# Altium schematic sheet version1.SchDoc
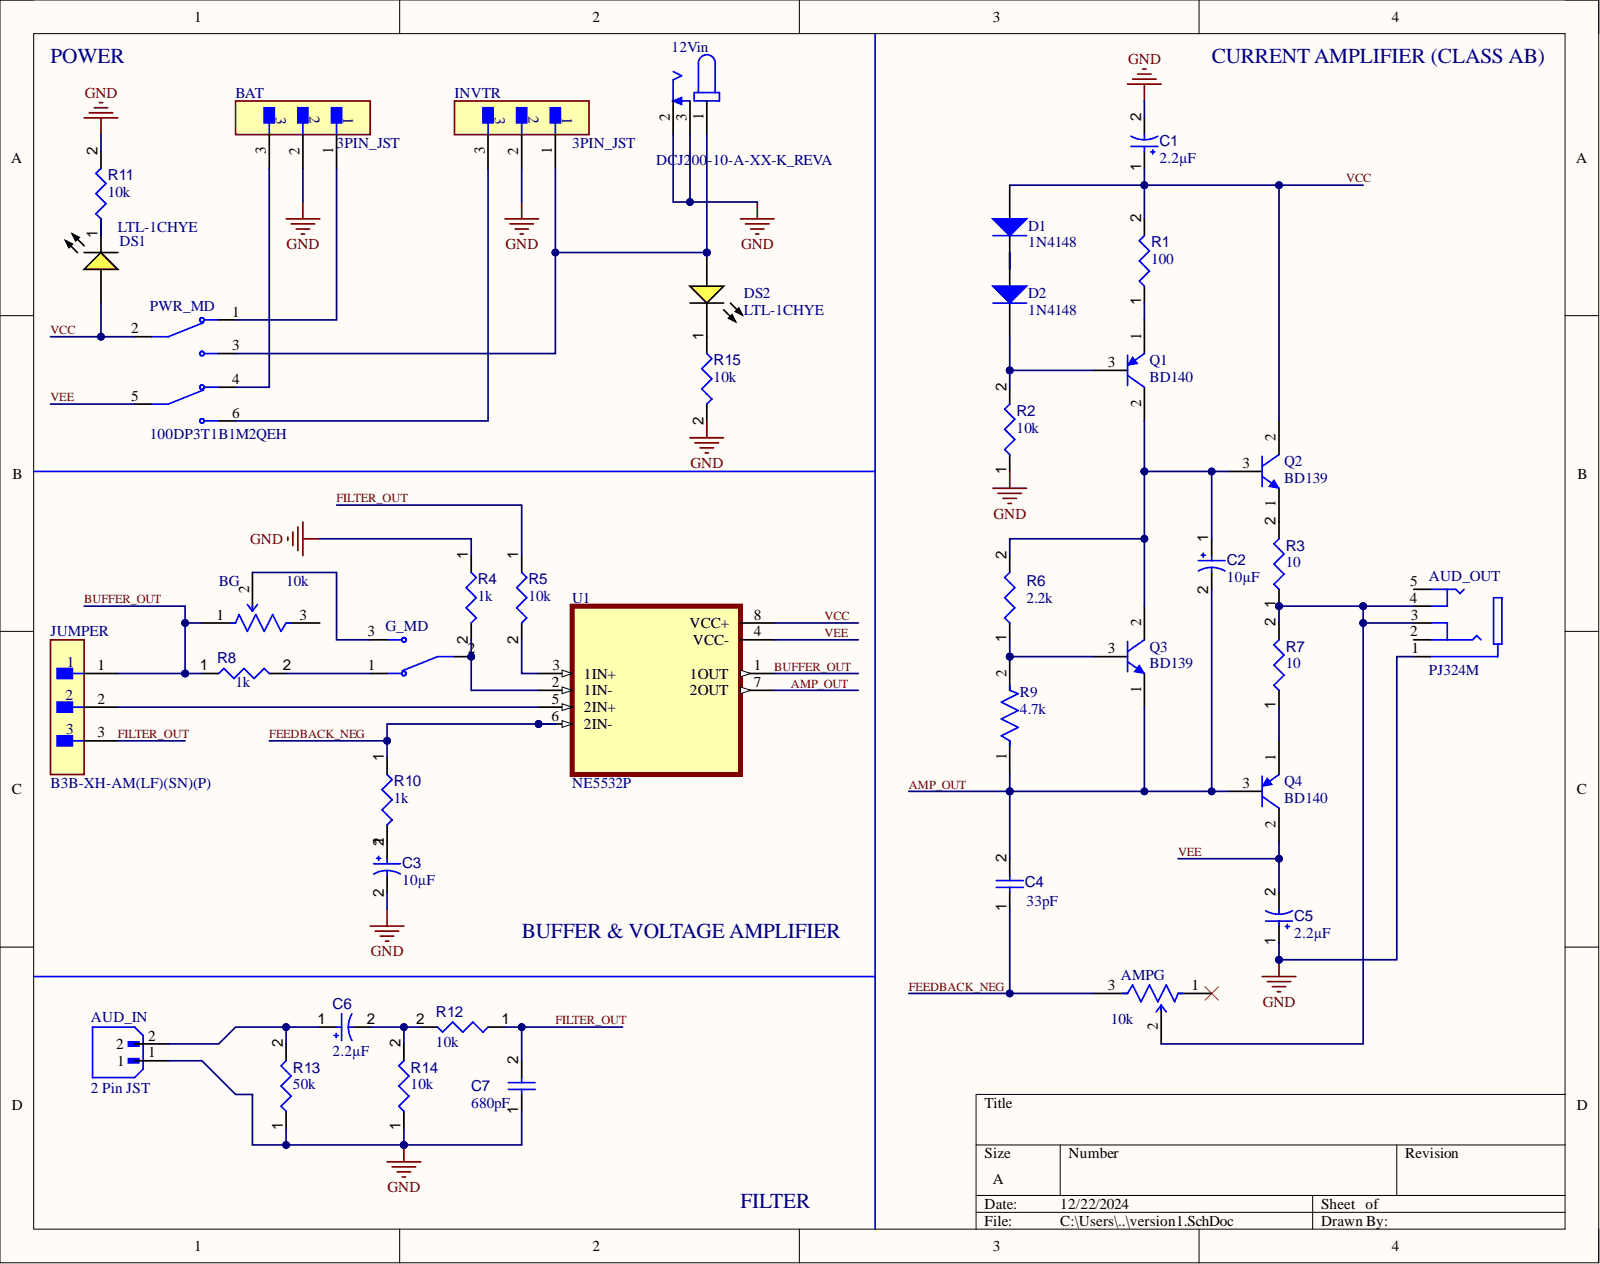
[diagram p0: version1.SchDoc]

## Parts
12Vin  DCJ200-10-A-XX-K_REVA  [GCT_DCJ200-10-A-XX-K_REVA]
AMPG  value 10k  [FP-248FGJSPXB25102MA-MFG - Cloned]  (hidden comment: 10K Potentiometer)
AUD_IN  2 Pin JST  [JST_B2B-XH-A(LF)(SN)]
AUD_OUT  PJ324M  [WENZHOU_PJ324M]
BAT  3PIN_JST  [FP-B3B-XH-AM_LF_SN_P-MFG]
BG  value 10k  [FP-248FGJSPXB25102MA-MFG - Cloned]  (hidden comment: 10K Potentiometer)
C1  value 2.2µF  [CAPPR55-200-1100X500X1200]  (hidden comment: ECA1HM2R2)
C2  value 10µF  [CAPPR55-200-1100X500X1200]  (hidden comment: ECA1HM100)
C3  value 10µF  [CAPPR55-200-1100X500X1200]  (hidden comment: ECA1HM100)
C4  value 33pF  [FP-D330G20C0GH63J5R-MFG]  (hidden comment: 33pF THT Capacitor)
C5  value 2.2µF  [CAPPR55-200-1100X500X1200]  (hidden comment: ECA1HM2R2)
C6  value 2.2µF  [CAPPR55-200-1100X500X1200]  (hidden comment: ECA1HM2R2)
C7  value 680pF  [FP-RCE0DB-MFG]  (hidden comment: 680pF THT Capacitor)
D1  1N4148  [NXP-SOD27-2_V]
D2  1N4148  [NXP-SOD27-2_V]
DS1  LTL-1CHYE  [LTST-LTL-1CHYE]
DS2  LTL-1CHYE  [LTST-LTL-1CHYE]
G_MD  (no value)  [SW_100SP1T1B4M2QE]
INVTR  3PIN_JST  [FP-B3B-XH-AM_LF_SN_P-MFG]
JUMPER  B3B-XH-AM(LF)(SN)(P)  [3 PIN HEADER]
PWR_MD  100DP3T1B1M2QEH  [SW_100DP3T1B1M2QEH]
Q1  BD140  [ONSC-TO-225-3-77-09]
Q2  BD139  [ONSC-TO-225-3-77-09]
Q3  BD139  [ONSC-TO-225-3-77-09]
Q4  BD140  [ONSC-TO-225-3-77-09]
R1  value 100  [FP-CFR50J2K2-MFG]  (hidden comment: 100 0.5W THT Resistor)
R2  value 10k  [FP-CFR50J2K2-MFG]  (hidden comment: 10k 0.5W THT Resistor)
R3  value 10  [FP-CFR50J2K2-MFG]  (hidden comment: 10 0.5W THT Resistor)
R4  value 1k  [FP-CFR50J2K2-MFG]  (hidden comment: 10k 0.5W THT Resistor)
R5  value 10k  [FP-CFR50J2K2-MFG]  (hidden comment: 10k 0.5W THT Resistor)
R6  value 2.2k  [FP-CFR50J2K2-MFG]  (hidden comment: 2.2k 0.5W Resistor THT)
R7  value 10  [FP-CFR50J2K2-MFG]  (hidden comment: 10 0.5W THT Resistor)
R8  value 1k  [FP-CFR50J2K2-MFG]  (hidden comment: 10k 0.5W THT Resistor)
R9  value 4.7k  [FP-CFR50J2K2-MFG]  (hidden comment: 4.7k 0.5W Resistor THT)
R10  value 1k  [FP-CFR50J2K2-MFG]  (hidden comment: 10k 0.5W THT Resistor)
R11  value 10k  [FP-CFR50J2K2-MFG]  (hidden comment: 10k 0.5W THT Resistor)
R12  value 10k  [FP-CFR50J2K2-MFG]  (hidden comment: 10k 0.5W THT Resistor)
R13  value 50k  [FP-CFR50J2K2-MFG]  (hidden comment: 50k 0.5W THT Resistor)
R14  value 10k  [FP-CFR50J2K2-MFG]  (hidden comment: 10k 0.5W THT Resistor)
R15  value 10k  [FP-CFR50J2K2-MFG]  (hidden comment: 10k 0.5W THT Resistor)
U1  NE5532P  [DIP794W45P254L959H508Q8]

## Nets
GND: 12Vin.2 12Vin.3 AUD_IN.1 AUD_OUT.1 BAT.2 C1.2 C3.2 C5.1 C7.1 INVTR.2 R2.1 R4.1 R11.2 R13.1 R14.1 R15.2
AMP_OUT: C2.2 C4.2 Q3.1 Q4.3 R9.1 U1.7(2OUT)
BUFFER_OUT: BG.1 JUMPER.1 R8.1 U1.1(1OUT)
FEEDBACK_NEG: AMPG.3 C4.1 R10.1 U1.6(2IN-)
FILTER_OUT: C7.2 JUMPER.3 R5.1 R12.1
VCC: C1.1 D1.1 DS1.1 PWR_MD.2 Q2.2 R1.2 U1.8(VCC+)
VEE: C5.2 PWR_MD.5 Q4.2 U1.4(VCC-)
Net12Vin_1: 12Vin.1 DS2.1 INVTR.1 PWR_MD.3
NetAMPG_2: AMPG.2 AUD_OUT.3 AUD_OUT.4 R3.1 R7.2
NetAUD_IN_2: AUD_IN.2 C6.1 R13.2
NetBAT_1: BAT.1 PWR_MD.1
NetBAT_3: BAT.3 PWR_MD.4
NetBG_2: BG.2 G_MD.3
NetC2_1: C2.1 Q1.2 Q2.3 Q3.2 R6.2
NetC3_1: C3.1 R10.2
NetC6_2: C6.2 R12.2 R14.2
NetD1_2: D1.2 D2.1
NetD2_2: D2.2 Q1.3 R2.2
NetDS1_2: DS1.2 R11.1
NetDS2_2: DS2.2 R15.1
NetG_MD_1: G_MD.1 R8.2
NetG_MD_2: G_MD.2 R4.2 U1.2(1IN-)
NetINVTR_3: INVTR.3 PWR_MD.6
NetJUMPER_2: JUMPER.2 U1.5(2IN+)
NetQ1_1: Q1.1 R1.1
NetQ2_1: Q2.1 R3.2
NetQ3_3: Q3.3 R6.1 R9.2
NetQ4_1: Q4.1 R7.1
NetR5_2: R5.2 U1.3(1IN+)

Not connected: AMPG 1; AUD_OUT 2 5; BG 3

## Library data of the parts
12Vin = DCJ200-10-A-XX-K_REVA: Power Barrel Connector Jack 2.05mm ID (0.081), 5.50mm OD (0.217) Through Hole, Right Angle; MF: Global Connector Technology; MP: DCJ200-10-A-K1-K; Check_prices: https://www.snapeda.com/parts/DCJ200-10-A-K1-K/Global+Connector+Technology/view-part/?ref=eda; Component Kind: Standard; Package: None; SnapEDA_Link: https://www.snapeda.com/parts/DCJ200-10-A-K1-K/Global+Connector+Technology/view-part/?ref=snap; Library Name: GHA_SCHLib.SchLib
AMPG BG = potentiometer 10K: 10K Potentiometer, B10K; Component Kind: Standard; Library Name: GHA_SCHLib.SchLib
AUD_IN = B2B-XH-A(LF)(SN): Connector Header Through Hole 2 position 0.098 (2.50mm); MF: JST Sales America Inc.; Check_prices: https://www.snapeda.com/parts/B2B-XH-A(LF)(SN)/JST+Sales+America+Inc./view-part/?ref=eda; Component Kind: Standard; MP: B2B-XH-A(LF)(SN); Package: None; SnapEDA_Link: https://www.snapeda.com/parts/B2B-XH-A(LF)(SN)/JST+Sales+America+Inc./view-part/?ref=snap; Library Name: GHA_SCHLib.SchLib
AUD_OUT = PJ324M: Headphone Stereo jack/Audio connector; MF: Wenzhou QingPu Electronics Co.Ltd; Check_prices: https://www.snapeda.com/parts/PJ324M/Wenzhou+QingPu+Electronics+Co.Ltd/view-part/?ref=eda; Component Kind: Standard; MP: PJ324M; Package: Package; SnapEDA_Link: https://www.snapeda.com/parts/PJ324M/Wenzhou+QingPu+Electronics+Co.Ltd/view-part/?ref=snap; Library Name: GHA_SCHLib.SchLib
BAT INVTR JUMPER = JST-XH-3pin: CONN HEADER VERT 3POS 2.5MM; Connector Type: Male Pin; Number of Positions: 3; Pitch: 0.098inch; Color: Natural; Component Kind: Standard; Contact Plating: Tin; Contact Type: Male Pin; Mount: Through Hole; Number of Rows: 1; Package Quantity: 1000; Packaging: Bulk; Series: XH; Termination: Press-Fit
  BAT INVTR: Library Name: SchemLib.SchLib
  JUMPER: Library Name: GHA_SCHLib.SchLib
C1 C5 C6 = CMP-001-00029-7: Aluminum Electrolytic Capacitor, 2.2 uF, +/- 20%, 50 V, -40 to 85 degC, 2-Pin THD, RoHS, Bulk; Manufacturer: Panasonic; Ripple Current: 20mA; Voltage Rating: 50V; Capacitor Type: Electrolytic; Case/Package: Radial; Component Kind: Standard; Datasheet URL: https://industrial.panasonic.com/cdbs/www-data/pdf/RDF0000/ABA0000C1218.pdf; Datasheet Version: Rev. 05, 10/2016; Diameter: 5mm; Lead Pitch: 2mm; Manufacturer URL: http://www.panasonic.com/; Max Operating Temperature: 85°C; Min Operating Temperature: -40°C; Mounting Technology: TH; Package Description: 2-Pin Radial Lead, Dia 5 mm, Height 12 mm; Package Quantity: 200; Package Reference: CAPPR55-200-1100X500X1200; Packaging: Bulk; Pins: 2; Ripple Current (AC): 20mA; RoHS Compliant: True; Tolerance: 20%; Library Name: Kiran Gunathilaka
C2 C3 = CMP-001-00025-7: Aluminum Electrolytic Capacitor, 10 uF, +/- 20%, 50 V, -40 to 85 degC, 2-Pin THD, RoHS, Bulk; Manufacturer: Panasonic; Ripple Current: 65mA; Voltage Rating: 50V; Capacitor Type: Electrolytic; Case/Package: Radial; Component Kind: Standard; Datasheet URL: https://industrial.panasonic.com/cdbs/www-data/pdf/RDF0000/ABA0000C1218.pdf; Datasheet Version: Rev. 05, 10/2016; Diameter: 5mm; Lead Pitch: 2mm; Manufacturer URL: http://www.panasonic.com/; Max Operating Temperature: 85°C; Min Operating Temperature: -40°C; Mounting Technology: TH; Package Description: 2-Pin Radial Lead, Dia 5 mm, Height 12 mm; Package Quantity: 200; Package Reference: CAPPR55-200-1100X500X1200; Packaging: Bulk; Pins: 2; Ripple Current (AC): 65mA; RoHS Compliant: True; Tolerance: 20%; Library Name: Kiran Gunathilaka
C4 = CMP-001-00083-1: Ceramic Capacitor, Ceramic, 100V, 2% +Tol, 2% -Tol, C0G, 30ppm/Cel TC, 0.000033uF, Through Hole Mount; Multilayer: No; Capacitor Type: Ceramic; Component Kind: Standard; Dielectric Material: Ceramic; Height: 9mm; JESD-609 Code: e3; Length: 5mm; Max Operating Temperature: 125°C; Min Operating Temperature: -55°C; Mounting Technology: Through Hole; Package Shape: Disk; Package Style: RadialMeter; Packaging: Bulk; Pins: 2; Rated DC Voltage (URdc): 100V; RoHS Compliant: Yes; Temperature Characteristics Code: C0G; Terminal Finish: Tin; Terminal Pitch: 5mm; Termination: Wire; Tolerance  (fill in as +-): 2%; Tolerance (fill in as +-): 2%; Width: 3.5mm; Library Name: Kiran Gunathilaka
C7 = CMP-001-00084-1: RCER71H681K0DBH03A          Ceramic Capacitor, Multilayer, Ceramic, 50V, 10% +Tol, 10% -Tol, X7R, 15% TC, 0.00068uF, Through Hole Mount, 1410; Reference Standard: AEC-Q200; Capacitor Type: Ceramic; Case/Package: 1410; Component Kind: Standard; Dielectric Material: Ceramic; Height: 3.5mm; Length: 3.6mm; Max Operating Temperature: 125°C; Min Operating Temperature: -55°C; Package Style: RadialMeter; Packaging: Ammo Pack; Pins: 2; Rated DC Voltage (URdc): 50V; Reach SVHC Compliant: Yes; RoHS Compliant: Yes; Temperature Characteristics Code: X7R; Terminal Pitch: 2.5mm; Tolerance  (fill in as +-): 10%; Tolerance (fill in as +-): 10%; Width: 2.5mm; Library Name: Kiran Gunathilaka
D1 D2 = CMP-004-00000-2: High Speed Diode, 100 V, 0.45 A, -65 to 175 degC, 2-Pin SOD27, RoHS, Bulk; Manufacturer: Fairchild; Elements: 1; Forward Current: 300mA; Forward Voltage: 1V; Max Surge Current: 4A; Peak Reverse Current: 5uA; Power: 500mW; Recovery Time: 4ns; Case/Package: DO; Component Kind: Standard; Datasheet URL: http://www.nxp.com/documents/data_sheet/1N4148_1N4448.pdf; Datasheet Version: Aug-04; Diameter: 1.91mm; Manufacturer URL: https://www.fairchildsemi.com/; Max Operating Temperature: 175°C; Min Operating Temperature: -65°C; Package Quantity: 2000; Package Reference: SOD27; Package URL: http://www.nxp.com/documents/data_sheet/1N4148_1N4448.pdf; Packaging: Bulk; Pins: 2; RoHS Compliant: True; Library Name: Kiran Gunathilaka
DS1 DS2 = CMP-007-00020-2: LED Uni-Color Yellow, 60 mW, 20 mA, -55 to 100 degC, 2-Pin THD, RoHS, Bulk; Manufacturer: Lite-On; Forward Current: 20mA; Forward Voltage: 2.1V; Lens Style: Dome; Luminous Intensity: 40mcd; Viewing Angle: 45degrees; Case/Package: Radial; Colour: Yellow; Component Kind: Standard; Datasheet URL: https://datasheet.ciiva.com/4269/s-110-ltl-1chye-4269126.pdf; Datasheet Version: Rev. B, 07/2013; Illumination Colour: Yellow; Manufacturer URL: http://www.us.liteon.com/; Max Operating Temperature: 100°C; Min Operating Temperature: -55°C; Mounting Technology: TH; Nominal Vf: 2.1V; Package Description: 2-Pin Through Hole Device, Dia 3.8 mm, Pitch 2.54 mm; Package Quantity: 1000; Package Reference: LTL-1CHYE; Packaging: Bulk; Pins: 2; RoHS Compliant: True; Library Name: Kiran Gunathilaka
G_MD = 100SP1T1B4M2QE: Toggle Switch SPDT Through Hole; Availability: Unavailable; Component Kind: Standard; MP: 100SP1T1B4M2QE; Package: None; Price: None; Library Name: GHA_SCHLib.SchLib
Q1 Q4 = CMP-013-00036-1: Power Bipolar Transistor, 1.5A I(C), 80V V(BR)CEO, 1-Element, PNP, Silicon, TO-225AA, Plastic/Epoxy, 3 Pin; DC Current Gain-Min (hFE): 25; Frequency: 75MHz; Number of Elements: 1; Power Dissipation-Max (Abs): 8W; Qualification Status: Not Qualified; Surface Mount: No; Time @ Peak Reflow Temperature-Max (s): 30s; Transistor Application: AMPLIFIER; Collector-emitter Voltage-Max: 80V; Component Kind: Standard; JEDEC-95 Code: TO-225AA; JESD-30 Code: R-PSFM-T3; JESD-609 Code: e0; Max Collector Current: 1.5A; Max Operating Temperature: 150°C; Package Body Material: Plastic; Package Shape: Rectangular; Package Style: FLANGE MOUNTMeter; Peak Reflow Temperature (Cel): 235°C; Pins: 3; Polarity/Channel Type: PNP; Reach SVHC Compliant: No; RoHS Compliant: No; Terminal Finish: Tin; Terminal Form: Through Hole; Terminal Position: SINGLE; Transistor Element Material: SILICON; Library Name: Kiran Gunathilaka
Q2 Q3 = CMP-013-00035-1: Power Bipolar Transistor, 1.5A I(C), 80V V(BR)CEO, 1-Element, NPN, Silicon, TO-225AA, Plastic/Epoxy, 3 Pin; DC Current Gain-Min (hFE): 25; Frequency: 250MHz; Number of Elements: 1; Power Dissipation-Max (Abs): 8W; Qualification Status: Not Qualified; Surface Mount: No; Time @ Peak Reflow Temperature-Max (s): 30s; Transistor Application: AMPLIFIER; Collector-emitter Voltage-Max: 80V; Component Kind: Standard; JEDEC-95 Code: TO-225AA; JESD-30 Code: R-PSFM-T3; JESD-609 Code: e0; Max Collector Current: 1.5A; Max Operating Temperature: 150°C; Package Body Material: Plastic; Package Shape: Rectangular; Package Style: FLANGE MOUNTMeter; Peak Reflow Temperature (Cel): 235°C; Pins: 3; Polarity/Channel Type: NPN; Reach SVHC Compliant: No; RoHS Compliant: No; Terminal Finish: Tin; Terminal Form: Through Hole; Terminal Position: SINGLE; Transistor Element Material: SILICON; Library Name: Kiran Gunathilaka
R1 = CMP-009-00182-2: Fixed Resistor, Carbon Film, 0.5W, 100ohm, 350V, 5% +/-Tol, 1200ppm/Cel, Through Hole Mount; Manufacturer Series: CFR50; Power: 500mW; Rated Temperature: 70°C; Temperature Coefficient: 1200ppm/°C; Component Kind: Standard; JESD-609 Code: e3; Max Operating Temperature: 160°C; Min Operating Temperature: -55°C; Mounting Technology: Through Hole; Package Shape: Tubular; Packaging: Ammo Pack; Pins: 2; Resistor Type: FIXED RESISTOR; RoHS Compliant: Yes; Technology: CARBON FILM; Terminal Finish: Tin; Termination: Wire; Tolerance: 5%; Working Voltage: 350V; Library Name: Kiran Gunathilaka
R2 R4 R5 R8 R10-R12 R14 R15 = CMP-009-00179-1: Fixed Resistor, Carbon Film, 0.5W, 10000ohm, 350V, 5% +/-Tol, 1200ppm/Cel, Through Hole Mount; Power: 500mW; Component Kind: Standard; Max Operating Temperature: 160°C; Min Operating Temperature: -55°C; Mounting Technology: Through Hole; Pins: 2; RoHS Compliant: Yes; Tolerance: 5%; Library Name: Kiran Gunathilaka
R3 R7 = CMP-009-00181-1: Fixed Resistor, Carbon Film, 0.5W, 10ohm, 350V, 5% +/-Tol, 1200ppm/Cel, Through Hole Mount; Power: 500mW; Component Kind: Standard; Max Operating Temperature: 160°C; Min Operating Temperature: -55°C; Mounting Technology: Through Hole; Pins: 2; RoHS Compliant: Yes; Tolerance: 5%; Library Name: Kiran Gunathilaka
R6 = CMP-009-00178-1: Fixed Resistor, Carbon Film, 0.5W, 2200ohm, 350V, 5% +/-Tol, 1200ppm/Cel, Through Hole Mount; Power: 500mW; Component Kind: Standard; Max Operating Temperature: 160°C; Min Operating Temperature: -55°C; Mounting Technology: Through Hole; Pins: 2; RoHS Compliant: Yes; Tolerance: 5%; Library Name: Kiran Gunathilaka
R9 = CMP-009-00177-2: Fixed Resistor, Carbon Film, 0.5W, 4700ohm, 350V, 5% +/-Tol, 1200ppm/Cel, Through Hole Mount; Power: 500mW; Component Kind: Standard; Max Operating Temperature: 160°C; Min Operating Temperature: -55°C; Mounting Technology: Through Hole; Pins: 2; RoHS Compliant: Yes; Tolerance: 5%; Library Name: Kiran Gunathilaka
R13 = CMP-009-00180-1: Fixed Resistor, Carbon Film, 0.5W, 50000ohm, 350V, 5% +/-Tol, 1200ppm/Cel, Through Hole Mount; Power: 500mW; Component Kind: Standard; Max Operating Temperature: 160°C; Min Operating Temperature: -55°C; Mounting Technology: Through Hole; Pins: 2; RoHS Compliant: Yes; Tolerance: 5%; Library Name: Kiran Gunathilaka
U1 = NE5532P: Dual Low-Noise High-Speed Audio Operational Amplifier 8-PDIP 0 to 70; MF: Texas Instruments; Availability: Good; Component Kind: Standard; MP: NE5532P; Package: PDIP-8 Texas; Price: None; Purchase-URL: https://pricing.snapeda.com/search/part/NE5532P/?ref=eda; SnapEDA_Link: https://www.snapeda.com/parts/NE5532P/Texas%20Instruments/view-part/9039/?ref=snap; Library Name: GHA_SCHLib.SchLib
PWR_MD = 100DP3T1B1M2QEH: Availability: Unavailable; Component Kind: Standard; MP: 100DP3T1B1M2QEH; Package: None; Price: None; Library Name: GHA_SCHLib.SchLib
